# Altium schematic sheet Peripherals.SchDoc
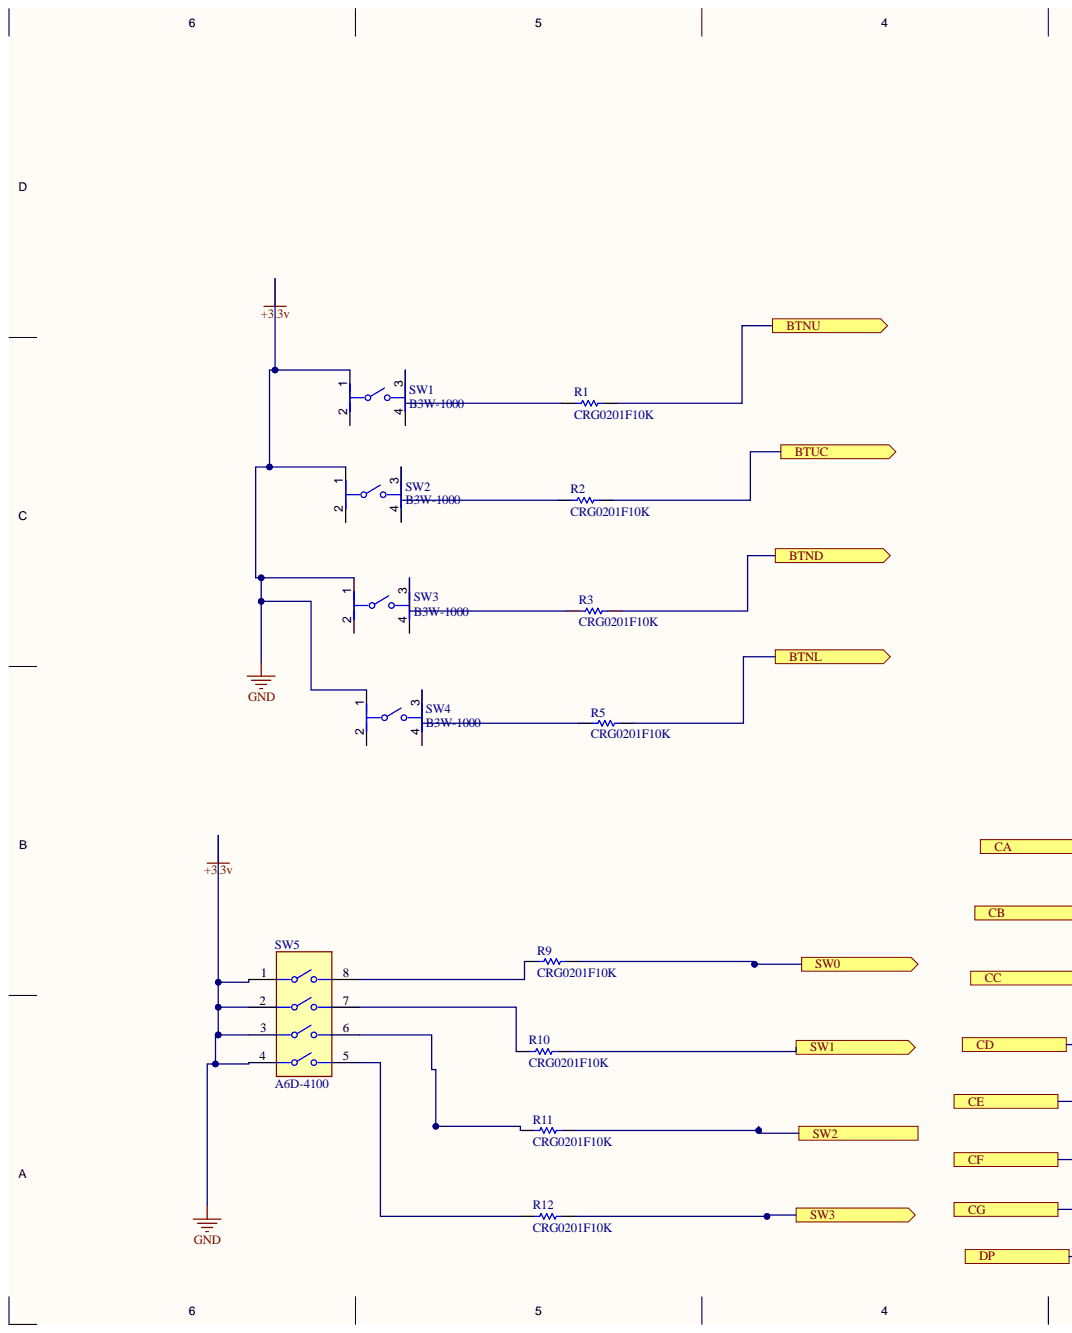
[diagram p0: Peripherals.SchDoc, left]
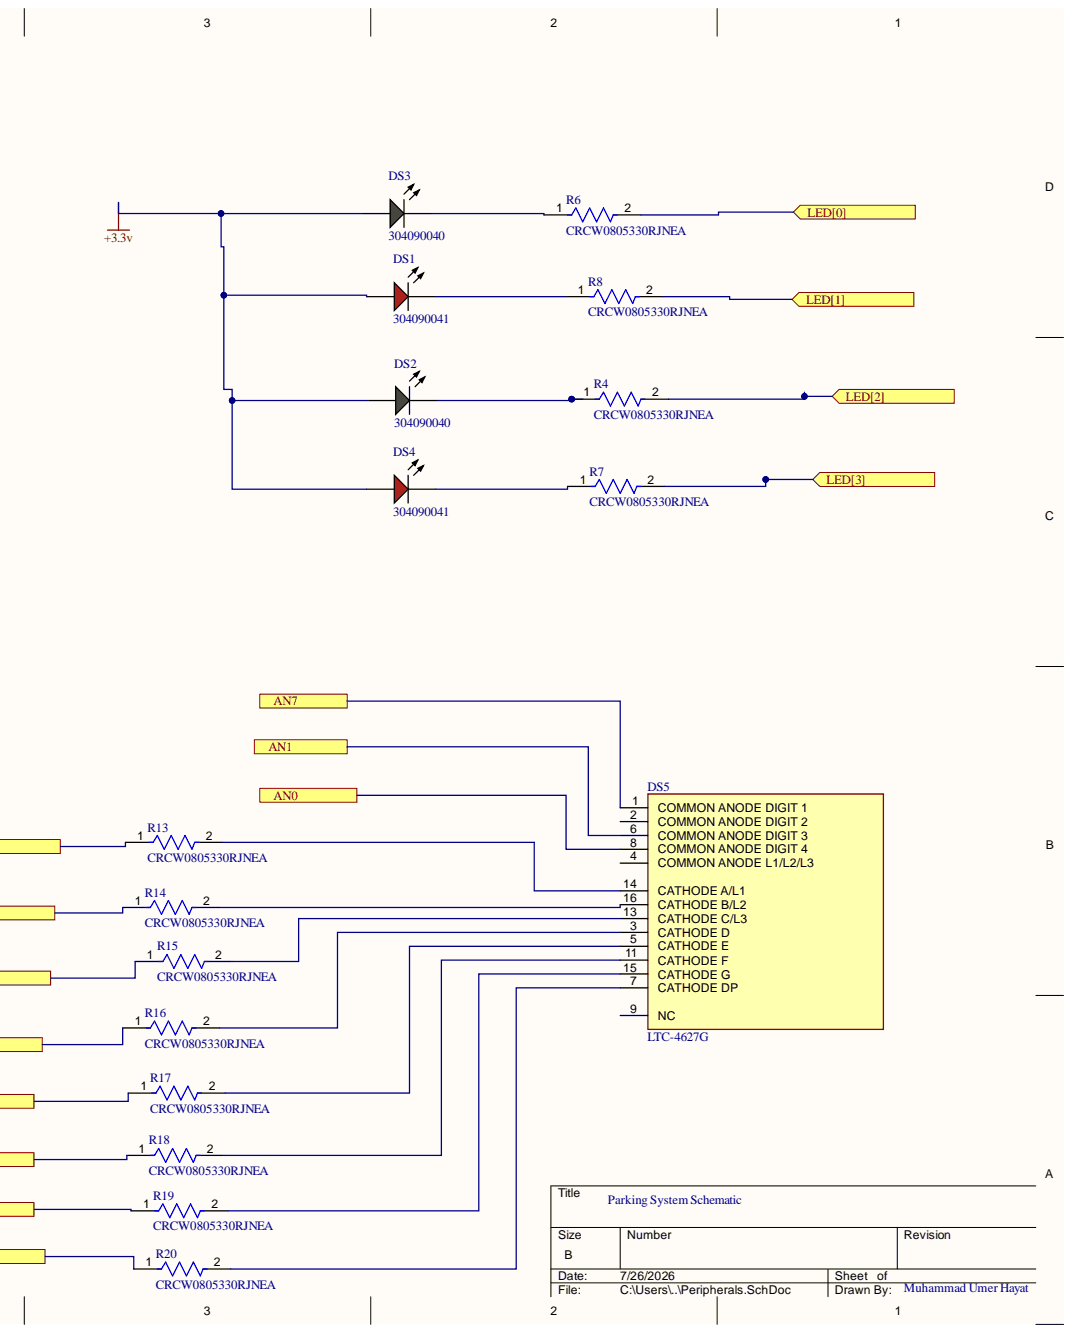
[diagram p1: Peripherals.SchDoc, right]

## Parts
DS1  304090041  [LED-2P-2.28-3MM_A]
DS2  304090040  [LED-2P-2.28-3MM]
DS3  304090040  [LED-2P-2.28-3MM]
DS4  304090041  [LED-2P-2.28-3MM_A]
DS5  LTC-4627G  [FP-LTC-4627G-MFG]
R1  CRG0201F10K  [RESC0603X26X15LL03T05]
R2  CRG0201F10K  [RESC0603X26X15LL03T05]
R3  CRG0201F10K  [RESC0603X26X15LL03T05]
R4  CRCW0805330RJNEA  [FP-CRCW0805-e3-IPC_C]
R5  CRG0201F10K  [RESC0603X26X15LL03T05]
R6  CRCW0805330RJNEA  [FP-CRCW0805-e3-IPC_C]
R7  CRCW0805330RJNEA  [FP-CRCW0805-e3-IPC_C]
R8  CRCW0805330RJNEA  [FP-CRCW0805-e3-IPC_C]
R9  CRG0201F10K  [RESC0603X26X15LL03T05]
R10  CRG0201F10K  [RESC0603X26X15LL03T05]
R11  CRG0201F10K  [RESC0603X26X15LL03T05]
R12  CRG0201F10K  [RESC0603X26X15LL03T05]
R13  CRCW0805330RJNEA  [FP-CRCW0805-e3-IPC_C]
R14  CRCW0805330RJNEA  [FP-CRCW0805-e3-IPC_C]
R15  CRCW0805330RJNEA  [FP-CRCW0805-e3-IPC_C]
R16  CRCW0805330RJNEA  [FP-CRCW0805-e3-IPC_C]
R17  CRCW0805330RJNEA  [FP-CRCW0805-e3-IPC_C]
R18  CRCW0805330RJNEA  [FP-CRCW0805-e3-IPC_C]
R19  CRCW0805330RJNEA  [FP-CRCW0805-e3-IPC_C]
R20  CRCW0805330RJNEA  [FP-CRCW0805-e3-IPC_C]
SW1  B3W-1000  [FP-B3W-1000-MFG]
SW2  B3W-1000  [FP-B3W-1000-MFG]
SW3  B3W-1000  [FP-B3W-1000-MFG]
SW4  B3W-1000  [FP-B3W-1000-MFG]
SW5  A6D-4100  [FP-A6D-4100-MFG]

## Ports
AN0, AN1, AN7, BTND, BTNL, BTNU, BTUC, CA, CB, CC, CD, CE, CF, CG, DP, LED[0], LED[1], LED[2], LED[3], SW0, SW1, SW2, SW3

## Nets
+3.3v: DS1.1 DS2.1 DS3.1 DS4.1 SW1.1 SW2.1 SW3.1 SW4.1 SW5.1 SW5.2 SW5.3 SW5.4
AN0: DS5.8(COMMON_ANODE_DIGIT_4) ; port AN0
AN1: DS5.6(COMMON_ANODE_DIGIT_3) ; port AN1
AN7: DS5.1(COMMON_ANODE_DIGIT_1) ; port AN7
BTND: R3.2 ; port BTND
BTNL: R5.2 ; port BTNL
BTNU: R1.2 ; port BTNU
BTUC: R2.2 ; port BTUC
CA: R13.1 ; port CA
CB: R14.1 ; port CB
CC: R15.1 ; port CC
CD: R16.1 ; port CD
CE: R17.1 ; port CE
CF: R18.1 ; port CF
CG: R19.1 ; port CG
DP: R20.1 ; port DP
LED[0]: R6.2 ; port LED[0]
LED[1]: R8.2 ; port LED[1]
LED[2]: R4.2 ; port LED[2]
LED[3]: R7.2 ; port LED[3]
SW0: R9.2 ; port SW0
SW1: R10.2 ; port SW1
SW2: R11.2 ; port SW2
SW3: R12.2 ; port SW3
NetDS1_2: DS1.2 R8.1
NetDS2_2: DS2.2 R4.1
NetDS3_2: DS3.2 R6.1
NetDS4_2: DS4.2 R7.1
NetDS5_3: DS5.3(CATHODE_D) R16.2
NetDS5_5: DS5.5(CATHODE_E) R17.2
NetDS5_7: DS5.7(CATHODE_DP) R20.2
NetDS5_11: DS5.11(CATHODE_F) R18.2
NetDS5_13: DS5.13(CATHODE_C/L3) R15.2
NetDS5_14: DS5.14(CATHODE_A/L1) R13.2
NetDS5_15: DS5.15(CATHODE_G) R19.2
NetDS5_16: DS5.16(CATHODE_B/L2) R14.2
NetR1_1: R1.1 SW1.3
NetR2_1: R2.1 SW2.3
NetR3_1: R3.1 SW3.3
NetR5_1: R5.1 SW4.3
NetR9_1: R9.1 SW5.8
NetR10_1: R10.1 SW5.7
NetR11_1: R11.1 SW5.6
NetR12_1: R12.1 SW5.5

Not connected: DS5 2(COMMON_ANODE_DIGIT_2) 4(COMMON_ANODE_L1/L2/L3) 9(NC); SW1 2 4; SW2 2 4; SW3 2 4; SW4 2 4

## Library data of the parts
DS1 DS4 = CMP-007-00007-2: Red LED, 80 mW, 30 mA, -25 to 85 degC, 2-Pin THD; Manufacturer: Shenzhen CGX; Case/Package: 304090041; Component Kind: Standard; Datasheet URL: http://datasheet.octopart.com/304090041-Chengguangxing-datasheet-62330656.pdf; Datasheet Version: Sep-14; Manufacturer URL: http://szcgx.com/; Mounting Technology: TH; Package Description: 2-Pin Through Hole Device, Dia 3 mm, Pitch 2.28 mm; Package Reference: 304090041; RoHS Compliant: Unknown; Library Name: Muhammad Umer Hayat
DS2 DS3 = CMP-007-00006-2: Green LED, 80 mW, 20 mA, -25 to 85 degC, 2-Pin THD; Manufacturer: Shenzhen CGX; Case/Package: 304090040; Component Kind: Standard; Datasheet URL: http://datasheet.octopart.com/304090040-Chengguangxing-datasheet-62330655.pdf; Datasheet Version: Rev. 1.0, 09/2014; Manufacturer URL: http://szcgx.com/; Mounting Technology: TH; Package Description: 2-Pin Through Hole Device, Dia 3 mm, Pitch 2.28 mm; Package Reference: 304090040; RoHS Compliant: Unknown; Library Name: Muhammad Umer Hayat
DS5 = CMP-2000-06610-2: LED 7-SEG 4DIGIT GRN 0.4" CA; Manufacturer: Lite-On; Element Config: Common Anode; Forward Current: 20mA; Forward Voltage: 2.1V; Luminous Intensity: 2.2mcd; Power Dissipation: 75mW; Reverse Voltage: 5V; Wavelength: 569nm; Automotive: No; Category: Disp; Component Kind: Standard; ComponentLink2Description: Datasheet; ComponentLink2URL: https://datasheet.ciiva.com/15136/getdatasheetpartid-630597-15136600.pdf; Device Class L1: Optoelectronics; Device Class L2: Displays; Device Class L3: LED Displays; Digikey Description: LED 7-SEG 4DIGIT GRN 0.4" CA; Display Type: LED; Height: 7.25mm; Lead Free: Yes; Library Name: Altium Content Vault; Manufacturer Part Number: LTC-4627G; Mouser Description: LED Displays & Accessories 4 Digit, Green; Octopart: https://octopart.com/ltc-4627g-lite-on-8442722; Package: 0; RoHS: TRUE; Temperature: -35°C to +85°C; Temperature Range High: +85°C; Temperature Range Low: -35°C; Test Current: 20mA
R1-R3 R5 R9-R12 = CMP-2004-03568-1: Manufacturer: TE Connectivity / AMP; Component Kind: Standard; Library Name: Altium Content Vault; Part Number: CRG0201F10K
R4 R6-R8 R13-R20 = CMP-2001-03824-2: RES Thick Film, 330Ω, 5%, 0.125W, 200ppm/°C, 0805; Max Power Dissipation: 125mW; Resistance: 330R; Voltage Rating: 150V; Case Code (Imperial): 0805; Case Code (Metric): 2012; Case/Package: 0805; Component Kind: Standard; Composition: Thick Film; Contact Plating: Tin; Depth: 1.25mm; Features: Automotive AEC-Q200; Height: 0.02inch; Lead Free: Lead Free; Length: 2mm; Library Name: Altium Content Vault; Max Operating Temperature: 155°C; Military Standard: Not; Min Operating Temperature: -55°C; Number of Terminations: 2; Package Quantity: 1; Packaging: Tape and Reel; Power Rating: 125mW; Radiation Hardening: No; Temperature Coefficient: 200ppm/°C; Termination: SMD/SMT; Tolerance: 5%; Voltage Rating (DC): 150V; Weight: 0.000194oz; Width: 0.049inch
SW1-SW4 = CMP-08834-000045-1: SWITCH TACTILE SPST-NO 0.05A 24V; Actuator Color: White; Actuator Length: 0.9mm; Actuator Type: Standard; Contact Current Rating: 50mA; Contact Resistance: 100mR; Illumination Type: Non-Illuminated; Mechanical Life: 1000000Cycles; Number of Poles: 1; Operating Force: 1.57N; Ratings: IP67; Switch Function: Off-Mom; Switch Travel: 0.25mm; Throw Configuration: SPST; Component Kind: Standard; Contact Material: Silver; Contact Plating: Silver; Current Rating: 50mA; Depth: 6.6mm; Insulation Resistance: 100MR; Length: 6.6mm; Library Name: Altium Content Vault; Max Current Rating: 50mA; Max Operating Temperature: 70°C; Max Voltage Rating (DC): 24V; Min Operating Temperature: -25°C; Mount: Through Hole; Orientation: Straight; Package Quantity: 100; Packaging: Bulk; Radiation Hardening: No; RoHS Compliant: Yes; Series: B3W; Termination: PC Pins; Voltage Rating (DC): 24V; Weight: 0.3g
SW5 = CMP-08827-000021-1: SWITCH SLIDE DIP SPST 30MA 30V; Actuator Length: 0mm; Actuator Type: Slide (Standard); Contact Current Rating: 100mA; Contact Resistance: 100mR; Electrical Life: 2000Cycles; Max Current Rating: 300mA; Mechanical Life: 5000Cycles; Number of Circuits: 4; Pitch: 0.1inch; Washable: Yes; Case/Package: DIP; Component Kind: Standard; Contact Material: Copper; Contact Plating: Gold; Current Rating: 30mA; Depth: 7.62mm; Insulation Resistance: 100MR; Lead Free: Lead Free; Length: 0.479inch; Library Name: Altium Content Vault; Max Operating Temperature: 70°C; Max Voltage Rating (DC): 30V; Min Operating Temperature: -20°C; Mount: Through Hole; Number of Poles: 4; Number of Positions: 4; Orientation: Straight; Package Quantity: 43; Packaging: Rail/Tube; Radiation Hardening: No; RoHS Compliant: Yes; Series: A6D; Terminal Pitch: 2.54mm; Termination: PC Pins; Throw Configuration: SPST; Voltage Rating (DC): 30V; Weight: 0.015873oz
